# Altium schematic sheet Sch4MainCardControl.SchDoc
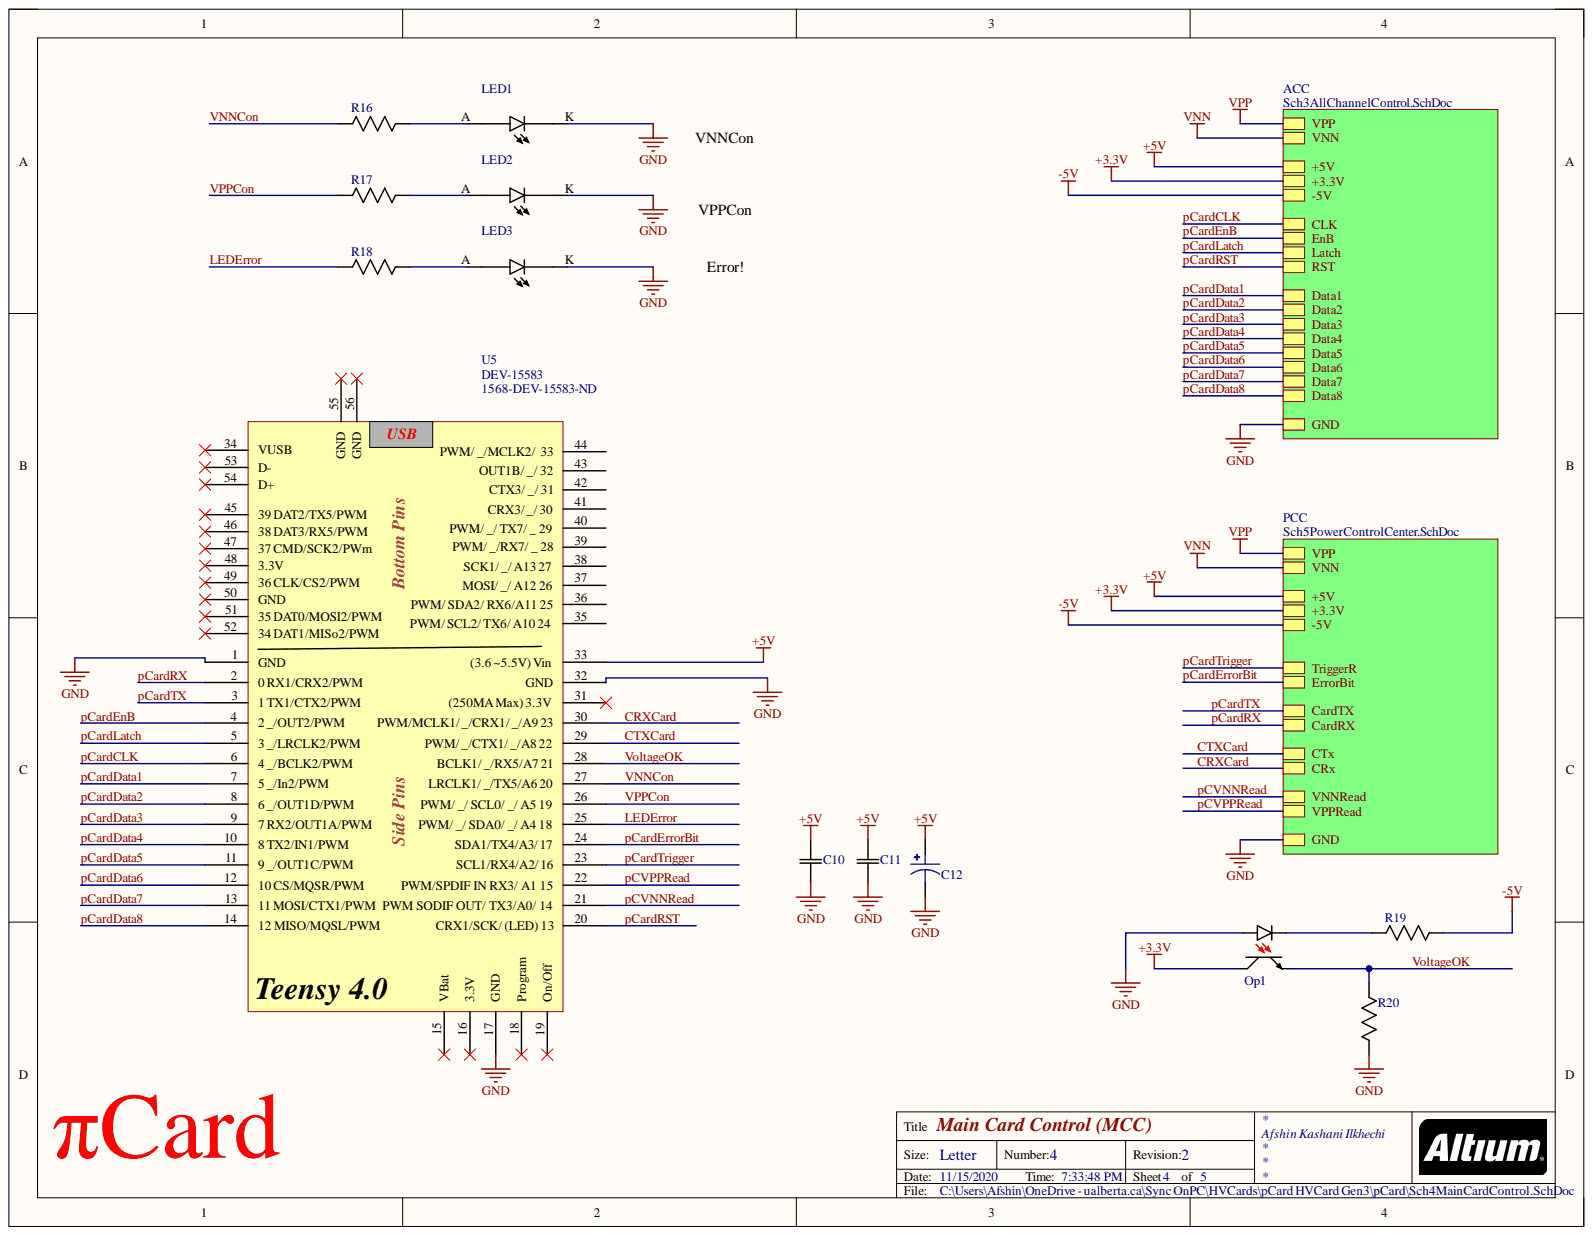
[diagram p0: Sch4MainCardControl.SchDoc]

## Parts
C10  GRM155R71C103MA01D  [C0402]  (value not drawn)
C11  GRM155R71C103MA01D  [C0402]  (value not drawn)
C12  T55A107M6R3C0200  [C1206]  (value not drawn)
LED1  LH R974-LP-1  [LEDr0805]  (value not drawn)
LED2  LH R974-LP-1  [LEDr0805]  (value not drawn)
LED3  LH R974-LP-1  [LEDr0805]  (value not drawn)
Op1  TLP291(GB,SE  [4-SOIC]  (value not drawn)
R16  ERA-2AEB331X  [0402]  (value not drawn)
R17  ERA-2AEB331X  [0402]  (value not drawn)
R18  ERA-2AEB331X  [0402]  (value not drawn)
R19  ERA-2AEB331X  [0402]  (value not drawn)
R20  RK73B1ETTP103J  [R0402]  (value not drawn)
U5  DEV-15583  Supplier Part Number 1: 1568-DEV-15583-ND  [Teensy 4.0]

## Nets
+3.3V: Op1.4
+5V: C10.2 C11.2 C12.1 U5.33((3.6_~5.5V)_Vin)
-5V: R19.1
GND: C10.1 C11.1 C12.2 LED1.K LED2.K LED3.K Op1.1 R20.1 U5.1(GND) U5.17(GND) U5.32(GND)
CRXCard: U5.30(PWM/MCLK1/__/CRX1/__/A9_23)
CTXCard: U5.29(PWM/__/CTX1/__/A8_22)
LEDError: R18.2 U5.25(PWM/__/_SDA0/__/_A4_18)
pCardCLK: U5.6(4__/BCLK2/PWM)
pCardData1: U5.7(5__/In2/PWM)
pCardData2: U5.8(6__/OUT1D/PWM)
pCardData3: U5.9(7_RX2/OUT1A/PWM)
pCardData4: U5.10(8_TX2/IN1/PWM)
pCardData5: U5.11(9__/OUT1C/PWM)
pCardData6: U5.12(10_CS/MQSR/PWM)
pCardData7: U5.13(11_MOSI/CTX1/PWM)
pCardData8: U5.14(12_MISO/MQSL/PWM)
pCardEnB: U5.4(2__/OUT2/PWM)
pCardErrorBit: U5.24(SDA1/TX4/A3/_17)
pCardLatch: U5.5(3__/LRCLK2/PWM)
pCardRST: U5.20(CRX1/SCK/_(LED)_13)
pCardRX: U5.2(0_RX1/CRX2/PWM)
pCardTrigger: U5.23(SCL1/RX4/A2/_16)
pCardTX: U5.3(1_TX1/CTX2/PWM)
pCVNNRead: U5.21
pCVPPRead: U5.22(PWM/SPDIF_IN_RX3/_A1_15)
VNNCon: R16.2 U5.27(LRCLK1/__/TX5/A6_20)
VoltageOK: Op1.3 R20.2 U5.28(BCLK1/__/RX5/A7_21)
VPPCon: R17.2 U5.26(PWM/__/_SCL0/__/_A5_19)
NetLED1_A: LED1.A R16.1
NetLED2_A: LED2.A R17.1
NetLED3_A: LED3.A R18.1
NetOp1_2: Op1.2 R19.2
NetU5_15: U5.15(VBat)
NetU5_16: U5.16(3.3V)
NetU5_18: U5.18(Program)
NetU5_19: U5.19(On/Off)
NetU5_31: U5.31((250MA_Max)_3.3V)
NetU5_34: U5.34(VUSB)
NetU5_35: U5.35(PWM/_SCL2/_TX6/_A10_24)
NetU5_36: U5.36(PWM/_SDA2/_RX6/A11_25)
NetU5_37: U5.37(MOSI/__/_A12_26)
NetU5_38: U5.38(SCK1/__/_A13_27)
NetU5_39: U5.39(PWM/__/RX7/___28)
NetU5_40: U5.40(PWM/__/_TX7/___29)
NetU5_41: U5.41(CRX3/__/_30)
NetU5_42: U5.42(CTX3/__/_31)
NetU5_43: U5.43(OUT1B/__/_32)
NetU5_44: U5.44
NetU5_45: U5.45(39_DAT2/TX5/PWM)
NetU5_46: U5.46(38_DAT3/RX5/PWM)
NetU5_47: U5.47(37_CMD/SCK2/PWm)
NetU5_48: U5.48(3.3V)
NetU5_49: U5.49(36_CLK/CS2/PWM)
NetU5_50: U5.50(GND)
NetU5_51: U5.51(35_DAT0/MOSI2/PWM)
NetU5_52: U5.52(34_DAT1/MISo2/PWM)
NetU5_53: U5.53(D-)
NetU5_54: U5.54(D+)
NetU5_55: U5.55(GND)
NetU5_56: U5.56(GND)
VNN:
VPP:

## Library data of the parts
C10 C11 = Cap_0402: Component Kind: Standard; Supplier 1: Digi-Key; Supplier Part Number 1: 490-16353-1-ND; Library Name: Afshin Library.IntLib
C12 = Cap_1206: Tantalium; Component Kind: Standard; Supplier 1: Mouser; Supplier Part Number 1: 74-T55A107M6R3C0200; Library Name: Afshin Library.IntLib
LED1-LED3 = LED_0805: LED:  LH R974-LP-1  LG R971-KN-1  LY R976-PS-36; Component Kind: Standard; Supplier 1: Digi-Key; Supplier Part Number 1: 475-1415-1-ND; Library Name: Afshin Library.IntLib
Op1 = TLP291: Component Kind: Standard; Supplier 1: Digi-Key; Supplier Part Number 1: TLP291(GBSE-ND; Library Name: Afshin Library.IntLib
R16-R19 = Res_0805: Component Kind: Standard; Supplier 1: Digi-Key; Supplier Part Number 1: P330DCCT-ND; Library Name: Afshin Library.IntLib
R20 = Res_0402: Component Kind: Standard; Supplier 1: Digi-Key; Supplier Part Number 1: 2019-RK73B1ETTP103JCT-ND; Library Name: Afshin Library.IntLib
U5 = Teensy 4.0: Component Kind: Standard; Supplier 1: Digi-Key; Library Name: Afshin Library.IntLib
